# Altium schematic sheet Flight_Computer.SchDoc
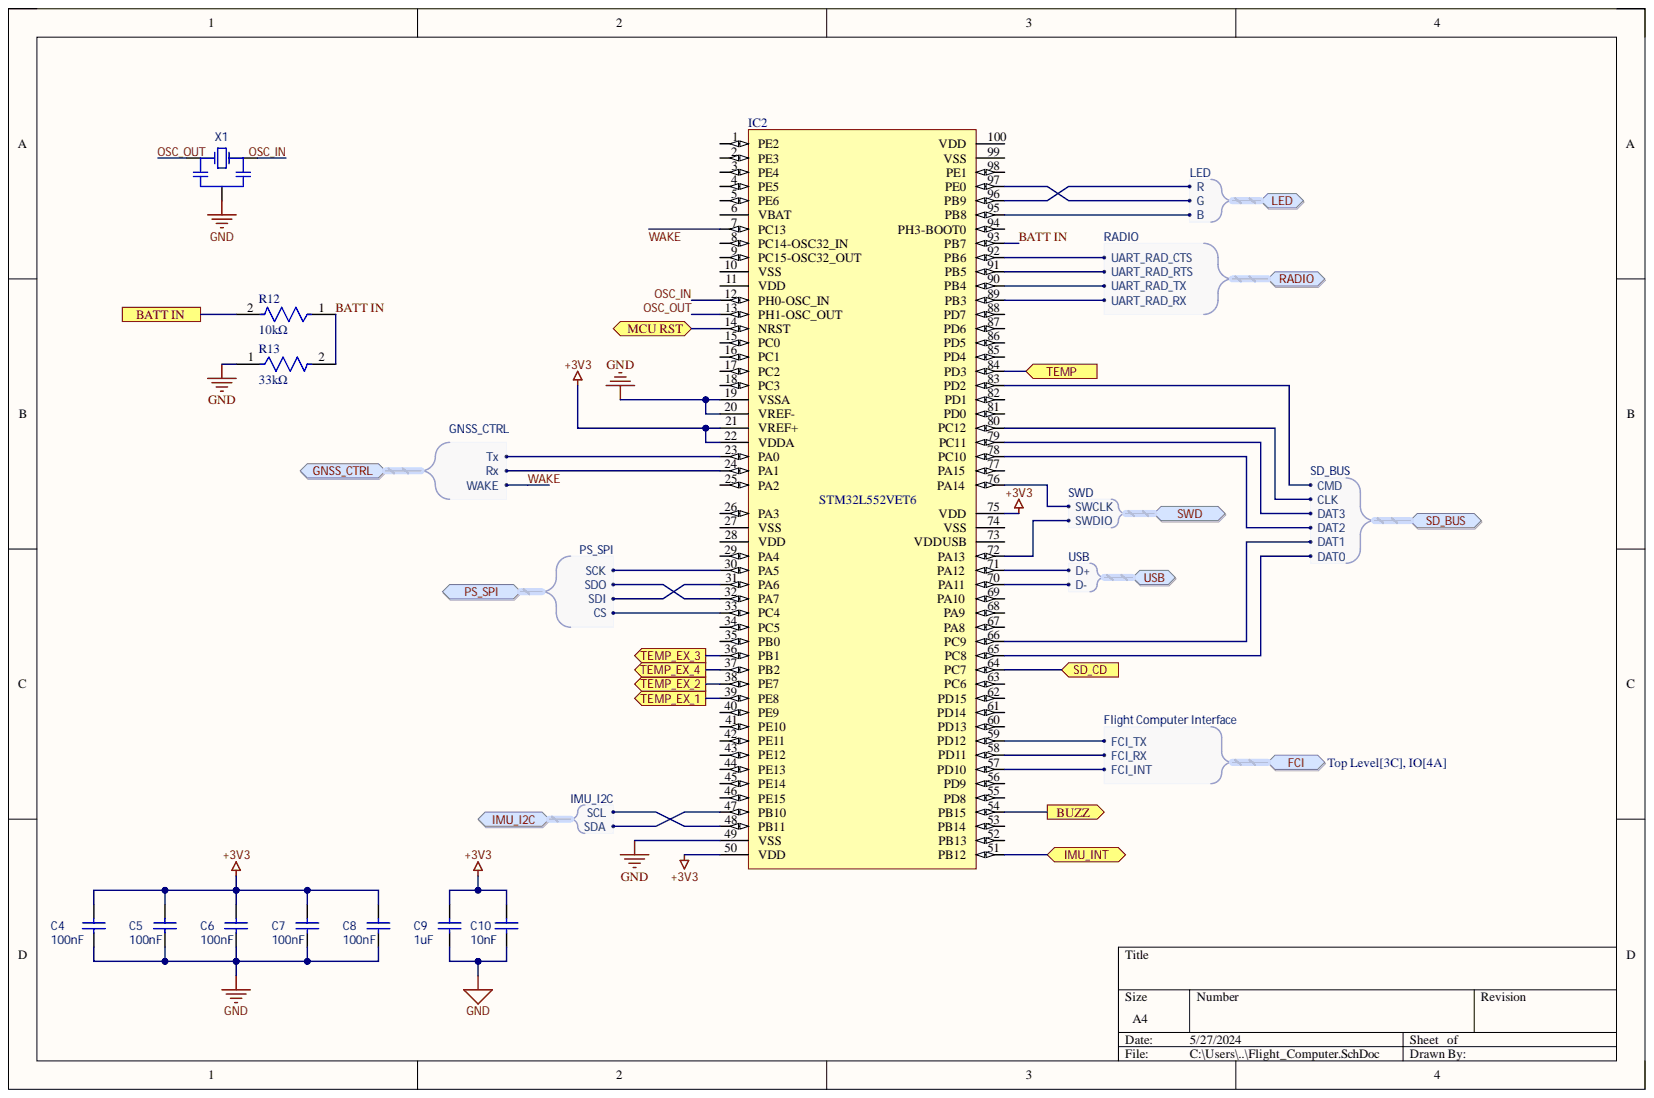
[diagram p0: Flight_Computer.SchDoc]

## Parts
C4  value 100nF  Capacitance: 100nF  [CAPC1608X10N]  (hidden comment: C0603C104M5RACTU)
C5  value 100nF  Capacitance: 100nF  [CAPC1608X10N]  (hidden comment: C0603C104M5RACTU)
C6  value 100nF  Capacitance: 100nF  [CAPC1608X10N]  (hidden comment: C0603C104M5RACTU)
C7  value 100nF  Capacitance: 100nF  [CAPC1608X10N]  (hidden comment: C0603C104M5RACTU)
C8  value 100nF  Capacitance: 100nF  [CAPC1608X10N]  (hidden comment: C0603C104M5RACTU)
C9  value 1uF  Capacitance: 1uF  [CAPC1608X10N]  (hidden comment: C0603C105K8PACTU)
C10  value 10nF  Capacitance: 10nF  [CAPC1608X10N]  (hidden comment: C0603C103J5RACTU)
IC2  STM32L552VET6  [TSQFP50P1600X1600X160-100N]
R12  10kΩ  [FP-RT0603-MFG]
R13  33kΩ  [FP-CRCW0603-e3-IPC_A]
X1  CSTNE16M0V530000R0  [FP-CSTNE16M0V530000R0-MFG]  (value not drawn)

## Ports
BATT IN, BUZZ, FCI, FCI.FCI_INT, FCI.FCI_RX, FCI.FCI_TX, GNSS_CTRL, GNSS_CTRL.Rx, GNSS_CTRL.Tx, GNSS_CTRL.WAKE, IMU_I2C, IMU_I2C.SCL, IMU_I2C.SDA, IMU_INT, LED, LED.B, LED.G, LED.R, MCU RST, PS_SPI, PS_SPI.CS, PS_SPI.SCK, PS_SPI.SDI, PS_SPI.SDO, RADIO, RADIO.UART_RAD_CTS, RADIO.UART_RAD_RTS, RADIO.UART_RAD_RX, RADIO.UART_RAD_TX, SD_BUS, SD_BUS.CLK, SD_BUS.CMD, SD_BUS.DAT0, SD_BUS.DAT1, SD_BUS.DAT2, SD_BUS.DAT3, SD_CD, SWD, SWD.SWCLK, SWD.SWDIO, TEMP, TEMP_EX_1, TEMP_EX_2, TEMP_EX_3, TEMP_EX_4, USB, USB.D+, USB.D-

## Nets
+3V3: C4.2 C5.2 C6.2 C7.2 C8.2 C9.2 C10.2 IC2.21(VREF+) IC2.22(VDDA) IC2.50(VDD) IC2.75(VDD)
GND: C4.1 C5.1 C6.1 C7.1 C8.1 C9.1 C10.1 IC2.19(VSSA) IC2.20(VREF-) IC2.49(VSS) R13.1 X1.2
BATT IN: IC2.93(PB7) R12.1 R13.2
BUZZ: IC2.54(PB15) ; port BUZZ
IMU_INT: IC2.51(PB12) ; port IMU_INT
MCU RST: IC2.14(NRST) ; port MCU RST
OSC_IN: IC2.12(PH0-OSC_IN) X1.1
OSC_OUT: IC2.13(PH1-OSC_OUT) X1.3
SD_CD: IC2.64(PC7) ; port SD_CD
TEMP: IC2.84(PD3) ; port TEMP
TEMP_EX_1: IC2.39(PE8) ; port TEMP_EX_1
TEMP_EX_2: IC2.38(PE7) ; port TEMP_EX_2
TEMP_EX_3: IC2.36(PB1) ; port TEMP_EX_3
TEMP_EX_4: IC2.37(PB2) ; port TEMP_EX_4
WAKE: IC2.7(PC13) ; port GNSS_CTRL
NetIC2_1: IC2.1(PE2)
NetIC2_2: IC2.2(PE3)
NetIC2_3: IC2.3(PE4)
NetIC2_4: IC2.4(PE5)
NetIC2_5: IC2.5(PE6)
NetIC2_6: IC2.6(VBAT)
NetIC2_8: IC2.8(PC14-OSC32_IN)
NetIC2_9: IC2.9(PC15-OSC32_OUT)
NetIC2_10: IC2.10(VSS)
NetIC2_11: IC2.11(VDD)
NetIC2_15: IC2.15(PC0)
NetIC2_16: IC2.16(PC1)
NetIC2_17: IC2.17(PC2)
NetIC2_18: IC2.18(PC3)
NetIC2_23: IC2.23(PA0) ; port GNSS_CTRL
NetIC2_24: IC2.24(PA1) ; port GNSS_CTRL
NetIC2_25: IC2.25(PA2)
NetIC2_26: IC2.26(PA3)
NetIC2_27: IC2.27(VSS)
NetIC2_28: IC2.28(VDD)
NetIC2_29: IC2.29(PA4)
NetIC2_30: IC2.30(PA5) ; port PS_SPI
NetIC2_31: IC2.31(PA6) ; port PS_SPI
NetIC2_32: IC2.32(PA7) ; port PS_SPI
NetIC2_33: IC2.33(PC4) ; port PS_SPI
NetIC2_34: IC2.34(PC5)
NetIC2_35: IC2.35(PB0)
NetIC2_40: IC2.40(PE9)
NetIC2_41: IC2.41(PE10)
NetIC2_42: IC2.42(PE11)
NetIC2_43: IC2.43(PE12)
NetIC2_44: IC2.44(PE13)
NetIC2_45: IC2.45(PE14)
NetIC2_46: IC2.46(PE15)
NetIC2_47: IC2.47(PB10) ; port IMU_I2C
NetIC2_48: IC2.48(PB11) ; port IMU_I2C
NetIC2_52: IC2.52(PB13)
NetIC2_53: IC2.53(PB14)
NetIC2_55: IC2.55(PD8)
NetIC2_56: IC2.56(PD9)
NetIC2_57: IC2.57(PD10) ; port FCI
NetIC2_58: IC2.58(PD11) ; port FCI
NetIC2_59: IC2.59(PD12) ; port FCI
NetIC2_60: IC2.60(PD13)
NetIC2_61: IC2.61(PD14)
NetIC2_62: IC2.62(PD15)
NetIC2_63: IC2.63(PC6)
NetIC2_65: IC2.65(PC8) ; port SD_BUS
NetIC2_66: IC2.66(PC9) ; port SD_BUS
NetIC2_67: IC2.67(PA8)
NetIC2_68: IC2.68(PA9)
NetIC2_69: IC2.69(PA10)
NetIC2_70: IC2.70(PA11) ; port USB
NetIC2_71: IC2.71(PA12) ; port USB
NetIC2_72: IC2.72(PA13) ; port SWD
NetIC2_73: IC2.73(VDDUSB)
NetIC2_74: IC2.74(VSS)
NetIC2_76: IC2.76(PA14) ; port SWD
NetIC2_77: IC2.77(PA15)
NetIC2_78: IC2.78(PC10) ; port SD_BUS
NetIC2_79: IC2.79(PC11) ; port SD_BUS
NetIC2_80: IC2.80(PC12) ; port SD_BUS
NetIC2_81: IC2.81(PD0)
NetIC2_82: IC2.82(PD1)
NetIC2_83: IC2.83(PD2) ; port SD_BUS
NetIC2_85: IC2.85(PD4)
NetIC2_86: IC2.86(PD5)
NetIC2_87: IC2.87(PD6)
NetIC2_88: IC2.88(PD7)
NetIC2_89: IC2.89(PB3) ; port RADIO
NetIC2_90: IC2.90(PB4) ; port RADIO
NetIC2_91: IC2.91(PB5) ; port RADIO
NetIC2_92: IC2.92(PB6) ; port RADIO
NetIC2_94: IC2.94(PH3-BOOT0)
NetIC2_95: IC2.95(PB8) ; port LED
NetIC2_96: IC2.96(PB9) ; port LED
NetIC2_97: IC2.97(PE0) ; port LED
NetIC2_98: IC2.98(PE1)
NetIC2_99: IC2.99(VSS)
NetIC2_100: IC2.100(VDD)
NetR12_2: R12.2 ; port BATT IN

## Library data of the parts
C4-C8 = CMP-00038-5: Cap Ceramic 0.1uF 50V X7R 20% SMD 0603 125C T/R; Dissipation Factor: 2.5%; Case Code (Imperial): 0603; Case Code (Metric): 1608; Case/Package: 0603; Component Kind: Standard; Contact Plating: Tin,Matte; Depth: 0.8mm; Dielectric: X7R; Dielectric Material: Ceramic; Height: 0.8mm; JLC_PN: C14663; Lead Pitch: 0.7mm; Length: 1.6mm; Max Operating Temperature: 125°C; Min Operating Temperature: -55°C; Mount: Surface Mount,PCB; Package Quantity: 1; Packaging: Tape and Reel; Radiation Hardening: No; REACH SVHC: No SVHC; Termination: SMD/SMT; Thickness: 0.034inch; Tolerance: 20%; Voltage Rating: 50V; Voltage Rating (DC): 50V; Width: 0.031inch; Library Name: UWA Aerospace
C9 = CMP-00045-2: Cap Ceramic 1uF 10V X5R 10% SMD 0603 85°C Paper T/R; Case Code (Imperial): 0603; Case Code (Metric): 1608; Case/Package: 0603; Component Kind: Standard; Depth: 0.8mm; Dielectric: X5R; Dielectric Material: Ceramic; Height: 0.8mm; JLC_PN: C15849; Lead Free: Lead Free; Length: 1.6mm; Max Operating Temperature: 85°C; Min Operating Temperature: -55°C; Mount: Surface Mount; Number of Pins: 2; Package Quantity: 1; Packaging: Tape and Reel; Radiation Hardening: No; REACH SVHC: No SVHC; Termination: SMD/SMT; Thickness: 0.035inch; Tolerance: 10%; Voltage: 10V; Voltage Rating: 10V; Voltage Rating (DC): 10V; Weight: 7.1E-05oz; Width: 0.031inch; Library Name: UWA Aerospace
C10 = CMP-00047-2: Cap Ceramic 0.01uF 50V X7R 5% SMD 0603 125C Paper T/R; Case/Package: 0603; Component Kind: Standard; Depth: 0.8mm; Dielectric: X7R; Height: 0.8mm; JLC_PN: C57112; Length: 1.6mm; Max Operating Temperature: 125°C; Min Operating Temperature: -55°C; Mount: Surface Mount; Packaging: Tape and Reel; Radiation Hardening: No; Tolerance: 5%; Library Name: UWA Aerospace
IC2 = CMP-00012-1: STM32L Series 512 kB Flash 256 KB RAM SMT 32-Bit Microcontroller - LQFP-100; Component Kind: Standard; Library Name: UWA Aerospace
R12 = CMP-2000-04608-2: RES SMD 10K OHM 0.5% 1/10W 0603; Manufacturer: Yageo; Manufacturer Part Number: RT0603DRE0710KL; Power Rating: 0.1W; Temperature Coefficient: 50 ppm/°C; Tolerance: 0.005; Voltage Rating: 75V; Automotive: No; Category: Res; Component Kind: Standard; ComponentLink2Description: Datasheet; ComponentLink2URL: http://www.yageo.com/documents/recent/PYu-RT_1-to-0.01_RoHS_L_9.pdf; Composition: Thin Film; Device Class L1: Passive Components; Device Class L2: Resistors; Device Class L3: Chip SMD Resistors; Digikey Description: RES SMD 10K OHM 0.5% 1/10W 0603; Footprint Url: http://www.yageo.com/exep/pages/download/literatures/PYu-R_Mount_10.pdf; Height: 0.55mm; Ipc Land Pattern Name: RESC16080X45; Lead Free: Yes; Library Name: Altium Content Vault; Mouser Description: Thin Film Resistors - SMD 10K ohm 0.5% 1/10W; Octopart: https://octopart.com/rt0603dre0710kl-yageo-1185844; Package: RT0603; Resistance: 10KΩ; RoHS: TRUE; Series: RT; Temperature: -55°C to +155°C; Temperature Range High: +155°C; Temperature Range Low: -55°C; Value: 10KΩ
R13 = CMP-2000-03245-2: RES Thick Film, 33kΩ, 1%, 0.1W, 100ppm/°C, 0603; Max Power Dissipation: 100mW; Resistance: 33kR; Voltage Rating: 75V; Case Code (Imperial): 0603; Case Code (Metric): 1608; Case/Package: 0603; Component Kind: Standard; Composition: Thick Film; Contact Plating: Tin; Depth: 0.85mm; Features: Automotive AEC-Q200; Height: 0.45mm; Lead Free: Lead Free; Length: 1.55mm; Library Name: Altium Content Vault; Max Operating Temperature: 155°C; Military Standard: Not; Min Operating Temperature: -55°C; Mount: Surface Mount; Number of Terminations: 2; Package Quantity: 10; Packaging: Tape and Reel; Power Rating: 100mW; Radiation Hardening: No; Temperature Coefficient: 100ppm/°C; Termination: SMD/SMT; Tolerance: 1%; Voltage Rating (DC): 75V; Weight: 7.1E-05oz; Width: 0.033inch
X1 = CMP-00029-1: CER RES 16.0000MHZ 15PF SMD; Frequency: 16MHz; Frequency Stability: 0.3%; Frequency Tolerance: 0.5%; Impedance: 40R; Capacitance: 15pF; Case/Package: SMD/SMT; Component Kind: Standard; Composition: Ceramic; Max Operating Temperature: 85°C; Min Operating Temperature: -40°C; Mount: Surface Mount; Packaging: Tape and Reel; Library Name: UWA Aerospace
